# Altium schematic sheet Top.SchDoc(Top)
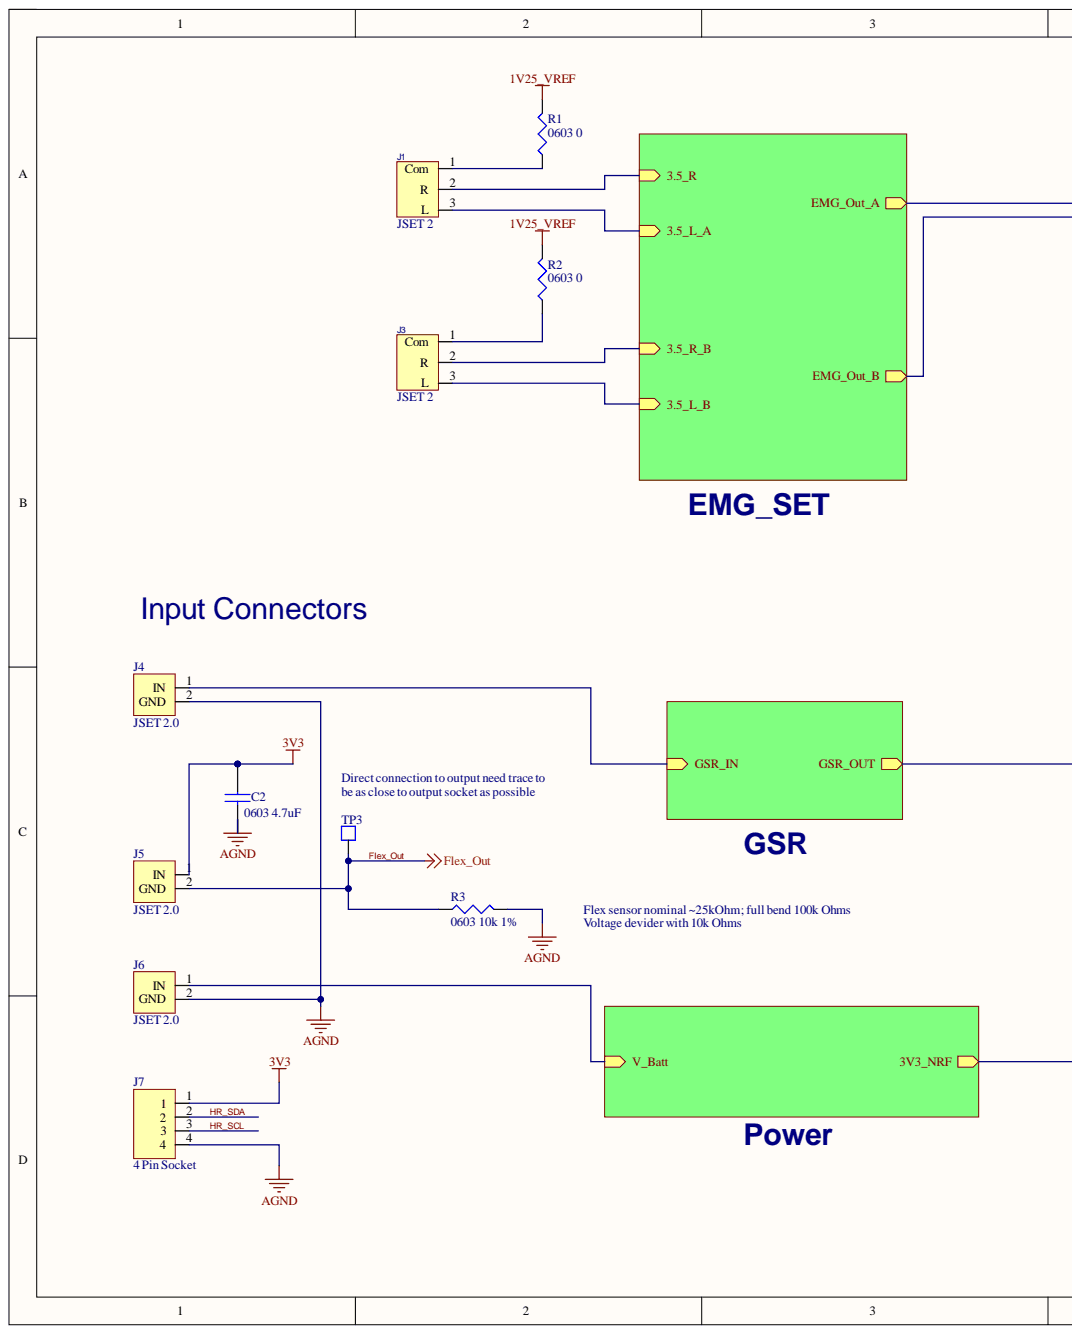
[diagram p0: Top.SchDoc, left]
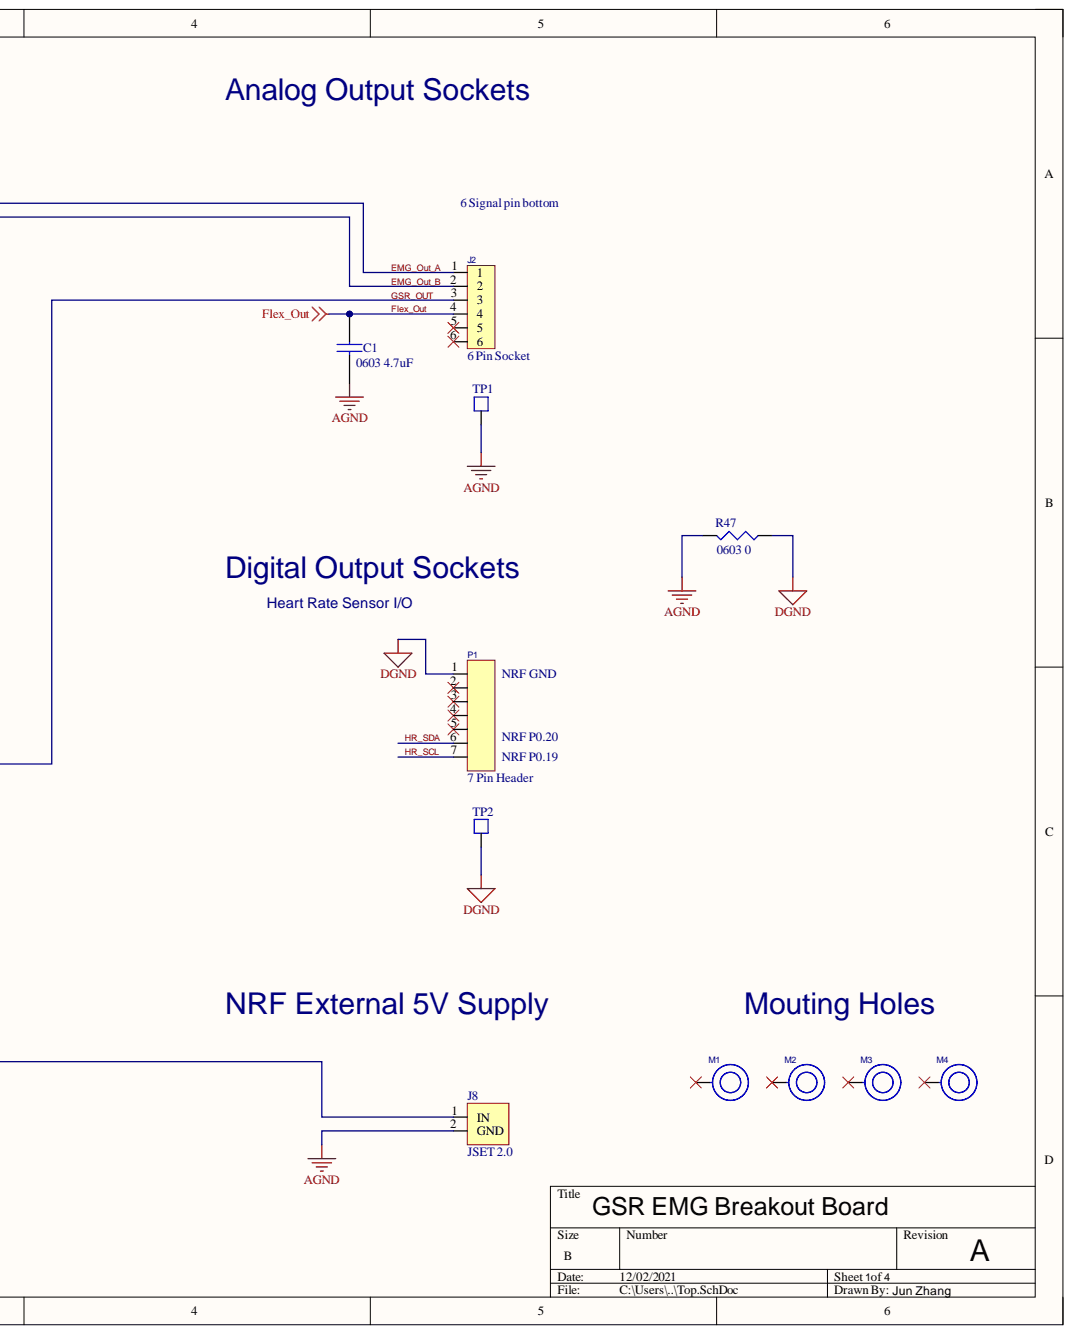
[diagram p1: Top.SchDoc, right]

## Parts
C1  0603 4.7uF  Capacitance: 4.7uF  Package: 0603  [CAP_0603_CER]
C2  0603 4.7uF  Capacitance: 4.7uF  Package: 0603  [CAP_0603_CER]
J1  JSET 2  [JST-XH3A]
J2  6 Pin Socket  [6 Pin Header]
J3  JSET 2  [JST-XH3A]
J4  JSET 2.0  [JST_XH2A]
J5  JSET 2.0  [JST_XH2A]
J6  JSET 2.0  [JST_XH2A]
J7  4 Pin Socket  [JST-XH4A]
J8  JSET 2.0  [JST_XH2A]
M1  Mounting Hole  [Mounting Hole]  (value not drawn)
M2  Mounting Hole  [Mounting Hole]  (value not drawn)
M3  Mounting Hole  [Mounting Hole]  (value not drawn)
M4  Mounting Hole  [Mounting Hole]  (value not drawn)
P1  7 Pin Header  [7 Pin Header]
R1  0603 0  [0603]
R2  0603 0  [0603]
R3  0603 10k 1%  Resistance: 10k  [0603]
R47  0603 0  [0603]
TP1  0402_TEST_PT  [0402_PAD]  (value not drawn)
TP2  0402_TEST_PT  [0402_PAD]  (value not drawn)
TP3  0402_TEST_PT  [0402_PAD]  (value not drawn)

## Nets
1V25_VREF: R1.1 R2.1
3V3: C2.1 J5.1 J7.1
AGND: C1.2 C2.2 J4.2 J6.2 J7.4 J8.2 R3.2 R47.1 TP1.1
DGND: P1.1 R47.2 TP2.1
EMG_Out_A: J2.1
EMG_Out_B: J2.2
Flex_Out: C1.1 J2.4 J5.2 R3.1 TP3.1
GSR_OUT: J2.3
HR_SCL: J7.3 P1.7
HR_SDA: J7.2 P1.6
NetJ1_1: J1.1 R1.2
NetJ1_2: J1.2
NetJ1_3: J1.3
NetJ2_5: J2.5
NetJ2_6: J2.6
NetJ3_1: J3.1 R2.2
NetJ3_2: J3.2
NetJ3_3: J3.3
NetJ4_1: J4.1
NetJ6_1: J6.1
NetJ8_1: J8.1
NetM1_1: M1.1
NetM2_1: M2.1
NetM3_1: M3.1
NetM4_1: M4.1
NetP1_2: P1.2
NetP1_3: P1.3
NetP1_4: P1.4
NetP1_5: P1.5

## Library data of the parts
C1 C2 = CAP_NP: Generic Cap for NC (No Polar)  ESR <30mOhm  ESL <1.5nH,  X5R; Manufacturer: Samsung Electro-Mechanics; Manufacturer Part Number: CL10A475KO8NNNC; Component Kind: Standard; ComponentLink1Description: New link; Dielectric: X5R; Supplier 1: Digi-Key; Supplier Part Number 1: 1276-1784-1-ND; Library Name: BioSens.SchLib
J1 J3 = JST 3 Pin: JST-XH3A; Component Kind: Standard; Library Name: BioSens.SchLib
J2 = 6 Pin Socket: Headers for Signal to NRF; Component Kind: Standard; Library Name: BioSens.SchLib
J4-J6 J8 = JST 2 Pin: PA Series 2 Position 2 mm Pitch Top Entry No Boss Through Hole Shrouded Header; Manufacturer: JST; Manufacturer Part Number: B02B-PASK(LF)(SN); Connector Type: Male Pin; Number of Contacts: 2; Pitch: 0.079inch; Category: Headers and Wire Housings; Color: Natural; Component Kind: Standard; ComponentLink1Description: https://datasheet.ciiva.com/712/epa-f-712485.pdf?src-supplier=Digi-Key; ComponentLink1URL: https://datasheet.ciiva.com/712/epa-f-712485.pdf?src-supplier=Digi-Key; Contact Material: Copper Alloy; Contact Mating Length: 0.126inch; Contact Plating: Tin; Contact Type: Male Pin; Fastening Type: Ramp; Housing Color: White; Lead Free: Lead Free; Mount: Through Hole; Number of Positions: 2; Number of Rows: 1; Packaging: Bulk; Pricing 1: 1=0.25000, 10=0.20800, 100=0.15980, 500=0.13522, 1000=0.11064, 5000=0.09835, 10000=0.08913 (USD); RoHS: Yes; Series: PA; Stock 1: 64007; Termination: Kinked Pin; Library Name: BioSens.SchLib
J7 = JST 4 Pin: Connector Header, PA Series, Top Entry , 4 Position, Male Pin, 1 Row, 2.0mm, Through Hole, Natural; Manufacturer: JST; Manufacturer Part Number: B04B-PASK(LF)(SN); Number of Contacts: 4; Pitch: 0.079inch; Category: Connectors; Color: Natural; Component Kind: Standard; ComponentLink1Description: https://datasheet.ciiva.com/712/epa-f-712564.pdf?src-supplier=Digi-Key; ComponentLink1URL: https://datasheet.ciiva.com/712/epa-f-712564.pdf?src-supplier=Digi-Key; Connector Type: Male Pin; Contact Material: Copper Alloy; Contact Mating Length: 0.126inch; Contact Plating: Tin; Contact Type: Male Pin; Fastening Type: Ramp; Housing Color: White; Lead Free: Lead Free; Mount: Through Hole; Number of Positions: 4; Number of Rows: 1; Packaging: Bulk; Pricing 1: 1=0.34000, 10=0.28700, 100=0.22360, 500=0.19162, 1000=0.15968, 5000=0.13687, 10000=0.12774 (USD); RoHS: Yes; Series: PA; Stock 1: 11525; Supplier 1: Digi-Key; Supplier Part Number 1: 455-1819-ND; Termination: Kinked Pin; Library Name: BioSens.SchLib
P1 = 7 Pin Socket: KK 254 Wire-to-Board Header Single Row Vertical 7 Circuits PA Polyamide Nylon Tin (Sn) Plating; Manufacturer: Molex; Contact Resistance: 10mR; Current Rating: 4A; Gender: Male; Glow Wire Compliant: Non-compliant; Insulation Resistance: 50GR; Max Voltage Rating (AC): 250V; Number of Circuits: 7; Pitch: 2.54mm; Category: Headers and Wire Housings; Color: White; Component Kind: Standard; ComponentLink1Description: https://datasheet.ciiva.com/5147/0022032071-pcb-headers-158424-5147929.pdf?src-supplier=Mouser; ComponentLink1URL: https://datasheet.ciiva.com/5147/0022032071-pcb-headers-158424-5147929.pdf?src-supplier=Mouser; ComponentLink2Description: https://datasheet.ciiva.com/11747/0900766b80027332-11747589.pdf?src-supplier=RSComponents; ComponentLink2URL: https://datasheet.ciiva.com/11747/0900766b80027332-11747589.pdf?src-supplier=RSComponents; ComponentLink3Description: https://datasheet.ciiva.com/16656/0022032071-pcb-headers-16656194.pdf?src-supplier=Avnet+Europe; ComponentLink3URL: https://datasheet.ciiva.com/16656/0022032071-pcb-headers-16656194.pdf?src-supplier=Avnet+Europe; ComponentLink4Description: https://datasheet.ciiva.com/19461/878614-19461332.pdf?src-supplier=Jameco; ComponentLink4URL: https://datasheet.ciiva.com/19461/878614-19461332.pdf?src-supplier=Jameco; ComponentLink5Description: https://datasheet.ciiva.com/10087/0022032071-pcb-headers-10087718.pdf?src-supplier=Avnet; ComponentLink5URL: https://datasheet.ciiva.com/10087/0022032071-pcb-headers-10087718.pdf?src-supplier=Avnet; ComponentLink6Description: https://datasheet.ciiva.com/1433/1533907-1433547.pdf?src-supplier=Element14; ComponentLink6URL: https://datasheet.ciiva.com/1433/1533907-1433547.pdf?src-supplier=Element14; ComponentLink7Description: https://datasheet.ciiva.com/1433/1831754-1433548.pdf?src-supplier=Element14; ComponentLink7URL: https://datasheet.ciiva.com/1433/1831754-1433548.pdf?src-supplier=Element14; ComponentLink8Description: https://datasheet.ciiva.com/15217/getdatasheetpartid-176575-15217532.pdf?src-supplier=Verical; ComponentLink8URL: https://datasheet.ciiva.com/15217/getdatasheetpartid-176575-15217532.pdf?src-supplier=Verical; Contact Gender: Male; Contact Material: Brass; Contact Mating Length: 0.295inch; Contact Plating: Tin,Copper; Contact Type: Male Pin; Depth: 2.34mm; Flammability Rating: UL94 V-0; Halogen Free: Low Halogen; Height: 6.3mm; Housing Color: White; Housing Material: Nylon; Lead Free: Lead Free; Length: 17.53mm; Manufacturer Part Number: 22-03-2071; Max Current Rating: 4A; Max Operating Temperature: 75°C; Min Operating Temperature: 0°C; Mount: Through Hole; Number of Contacts: 7; Number of Positions: 7; Number of Rows: 1; Orientation: Straight; Packaging: Bulk; Pricing 1: 1=0.82000, 10=0.69600, 100=0.54110, 1000=0.42516, 5000=0.37362, 10000=0.34785 (USD); Radiation Hardening: No; REACH SVHC: Unknown; RoHS: Yes; RoHS Compliant: Yes; Series: KK® 4030; Stock 1: 11624; Supplier 1: Digi-Key; Supplier Part Number 1: WM4005-ND; Termination: Solder; Voltage Rating: 250V; Voltage Rating (DC): 250V; Weight: 0.016932oz; Library Name: BioSens.SchLib
R1 R2 R47 = RESISTOR: Generic Resistor; Manufacturer: YAGEO; Resistance: 0; Component Kind: Standard; Package: 0603; Library Name: BioSens.SchLib
R3 = RESISTOR: Generic Resistor; Manufacturer: YAGEO; Component Kind: Standard; Package: 0603; Library Name: BioSens.SchLib
M1-M4 = Mounting Hole: Component Kind: Standard; Library Name: BioSens.SchLib
TP1-TP3 = 0402_TEST_PT: Component Kind: Standard; Library Name: BioSens.SchLib
